# Altium schematic sheet SynchrBuckConverter.SchDoc(SynchrBuckConverter)
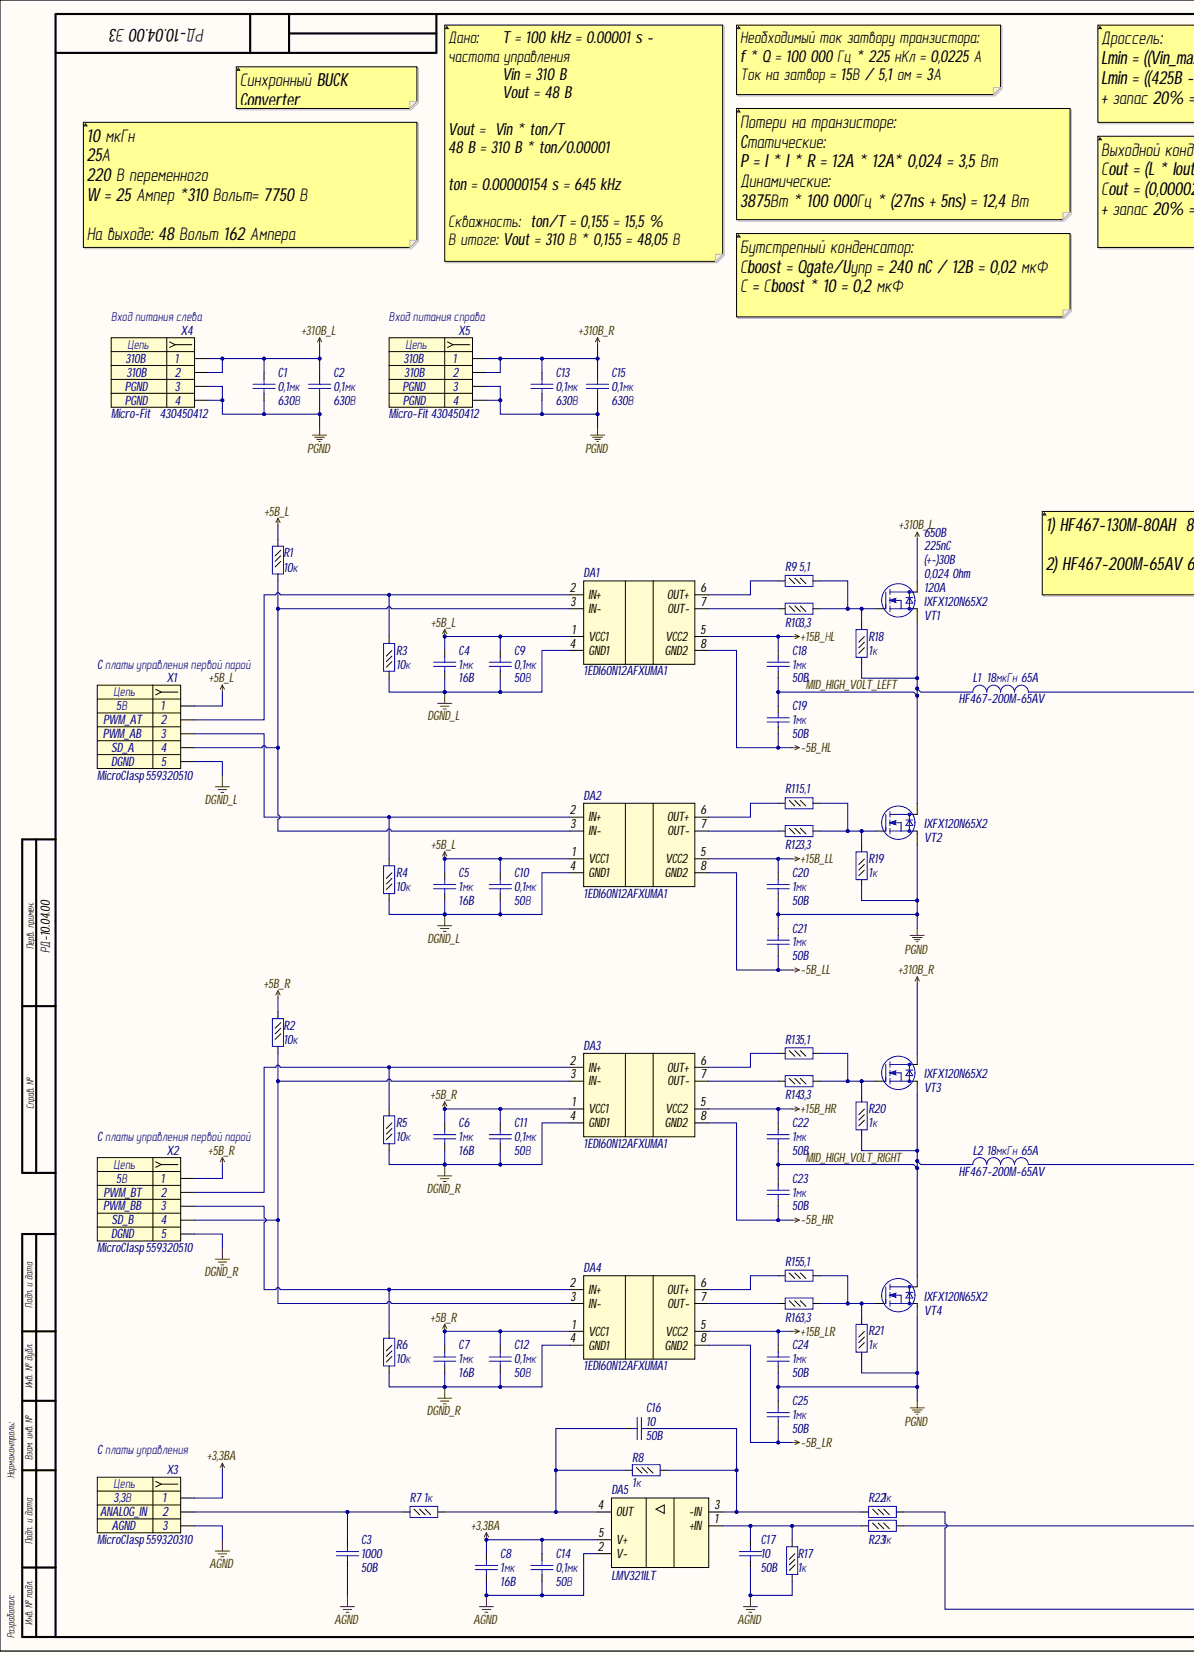
[diagram p0: SynchrBuckConverter.SchDoc, left]
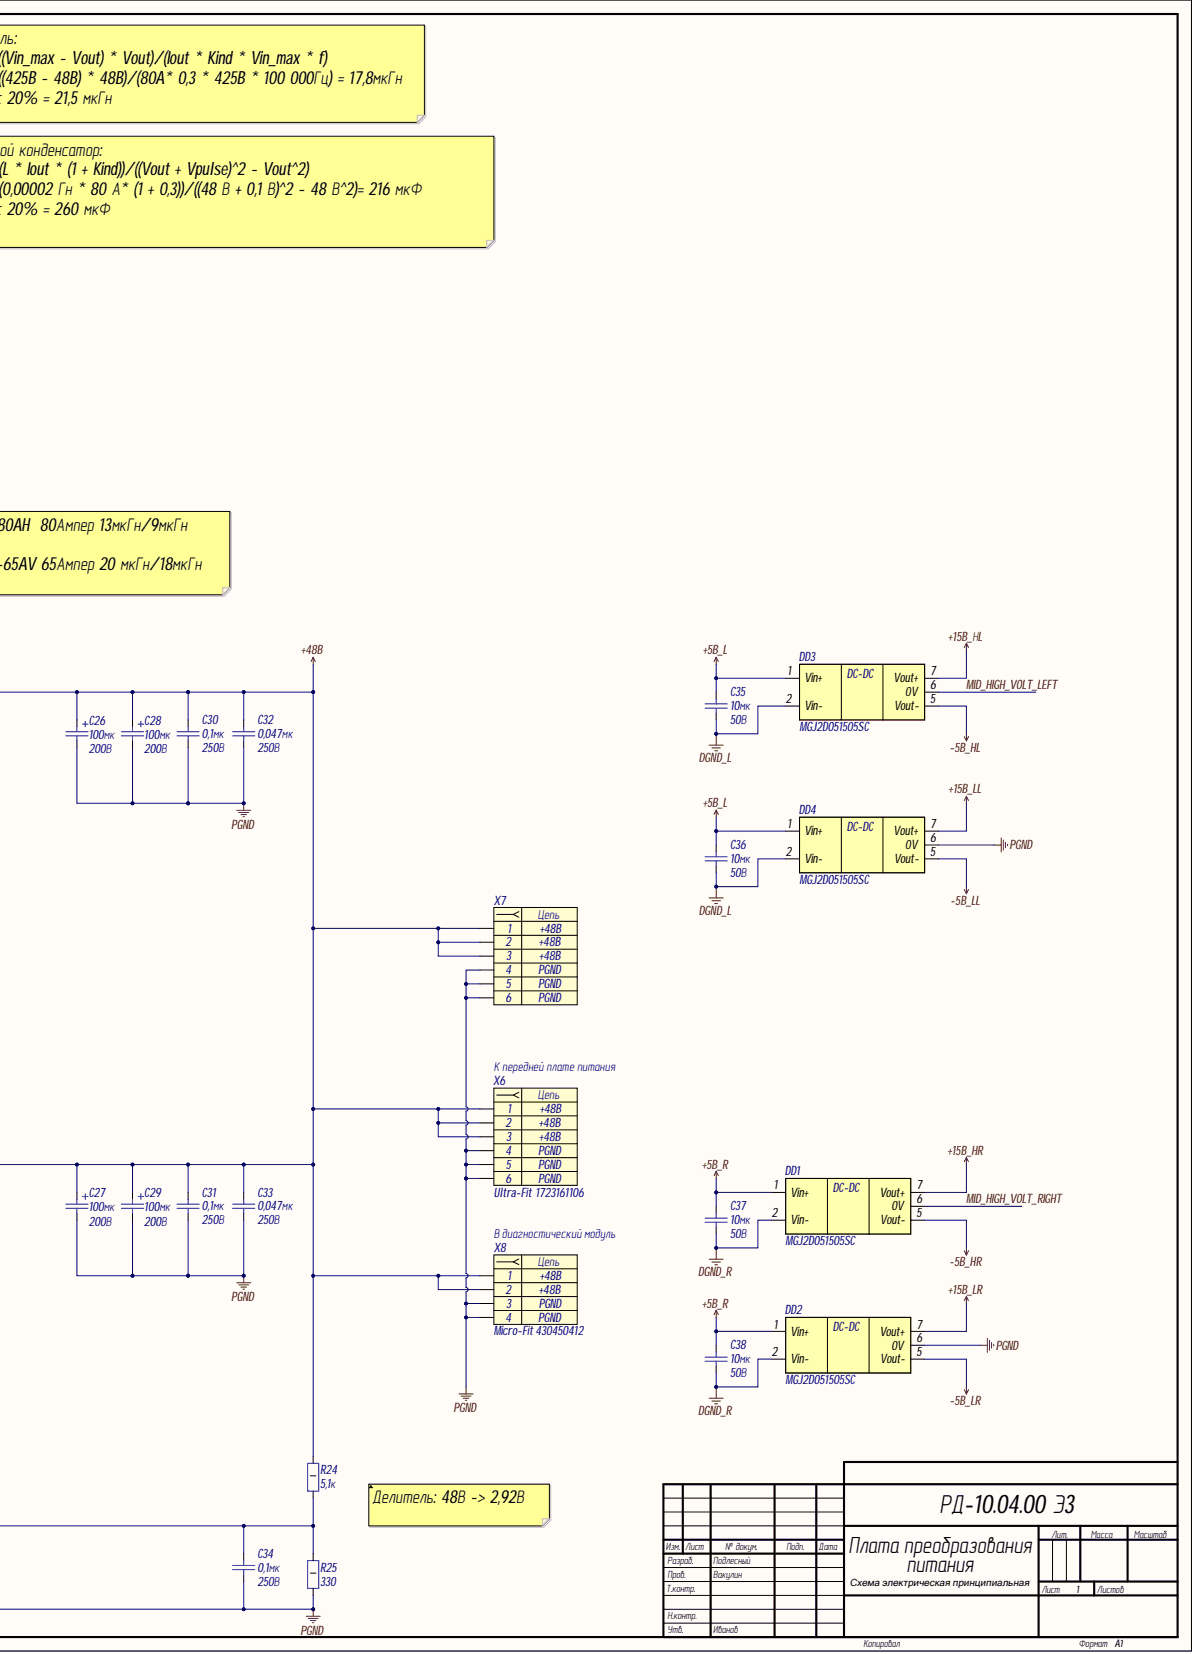
[diagram p1: SynchrBuckConverter.SchDoc, right]

## Parts
C1  0,1мк  Voltage: 630В  [C1812]
C2  0,1мк  Voltage: 630В  [C1812]
C3  value 1000  Voltage: 50B  [C0402]  (hidden comment: Capacitor)
C4  1мк  Voltage: 16B  [C0603]
C5  1мк  Voltage: 16B  [C0603]
C6  1мк  Voltage: 16B  [C0603]
C7  1мк  Voltage: 16B  [C0603]
C8  1мк  Voltage: 16B  [C0603]
C9  0,1мк  Voltage: 50В  [C0402]
C10  0,1мк  Voltage: 50В  [C0402]
C11  0,1мк  Voltage: 50В  [C0402]
C12  0,1мк  Voltage: 50В  [C0402]
C13  0,1мк  Voltage: 630В  [C1812]
C14  value 0,1мк  Voltage: 50В  [C0402]  (hidden comment: Capacitor)
C15  0,1мк  Voltage: 630В  [C1812]
C16  value 10  Voltage: 50B  [C0402]  (hidden comment: Capacitor)
C17  value 10  Voltage: 50B  [C0402]  (hidden comment: Capacitor)
C18  1мк  Voltage: 50B  [C0805]
C19  1мк  Voltage: 50B  [C0805]
C20  1мк  Voltage: 50B  [C0805]
C21  1мк  Voltage: 50B  [C0805]
C22  1мк  Voltage: 50B  [C0805]
C23  1мк  Voltage: 50B  [C0805]
C24  1мк  Voltage: 50B  [C0805]
C25  1мк  Voltage: 50B  [C0805]
C26  value 100мк  Voltage: 200В  [Cap_SMD_radial_polar(18x21.5)]  (hidden comment: Capacitor_pol)
C27  value 100мк  Voltage: 200В  [Cap_SMD_radial_polar(18x21.5)]  (hidden comment: Capacitor_pol)
C28  value 100мк  Voltage: 200В  [Cap_SMD_radial_polar(18x21.5)]  (hidden comment: Capacitor_pol)
C29  value 100мк  Voltage: 200В  [Cap_SMD_radial_polar(18x21.5)]  (hidden comment: Capacitor_pol)
C30  0,1мк  Voltage: 250В  [C1206]
C31  0,1мк  Voltage: 250В  [C1206]
C32  0,047мк  Voltage: 250В  [C1206]
C33  0,047мк  Voltage: 250В  [C1206]
C34  0,1мк  Voltage: 250В  [C1206]
C35  10мк  Voltage: 50В  [C1210]
C36  10мк  Voltage: 50В  [C1210]
C37  10мк  Voltage: 50В  [C1210]
C38  10мк  Voltage: 50В  [C1210]
DA1  1EDI60N12AF  Part Number: 1EDI60N12AFXUMA1  [PG-DSO-8]
DA2  1EDI60N12AF  Part Number: 1EDI60N12AFXUMA1  [PG-DSO-8]
DA3  1EDI60N12AF  Part Number: 1EDI60N12AFXUMA1  [PG-DSO-8]
DA4  1EDI60N12AF  Part Number: 1EDI60N12AFXUMA1  [PG-DSO-8]
DA5  LMV321ILT  [SOT23-5]
DD1  Part Number: MGJ2D051505SC  [SIP7]  (hidden comment: MGJ2DXX XXXX S C-RXX)
DD2  Part Number: MGJ2D051505SC  [SIP7]  (hidden comment: MGJ2DXX XXXX S C-RXX)
DD3  Part Number: MGJ2D051505SC  [SIP7]  (hidden comment: MGJ2DXX XXXX S C-RXX)
DD4  Part Number: MGJ2D051505SC  [SIP7]  (hidden comment: MGJ2DXX XXXX S C-RXX)
L1  value 18мкГн  Rated Current: 65A  [HF467-200M-65AV]  (hidden comment: Inductor)
L2  value 18мкГн  Rated Current: 65A  [HF467-200M-65AV]  (hidden comment: Inductor)
R1  value 10к  [R0402]  (hidden comment: Resistor_0,062Вт)
R2  value 10к  [R0402]  (hidden comment: Resistor_0,062Вт)
R3  value 10к  [R0402]  (hidden comment: Resistor_0,062Вт)
R4  value 10к  [R0402]  (hidden comment: Resistor_0,062Вт)
R5  value 10к  [R0402]  (hidden comment: Resistor_0,062Вт)
R6  value 10к  [R0402]  (hidden comment: Resistor_0,062Вт)
R7  value 1к  [R0402]  (hidden comment: Resistor_0,062Вт)
R8  value 1к  [R0402]  (hidden comment: Resistor_0,062Вт)
R9  value 5,1  [R0603]  (hidden comment: Resistor_0,062Вт)
R10  value 3,3  [R0603]  (hidden comment: Resistor_0,062Вт)
R11  value 5,1  [R0603]  (hidden comment: Resistor_0,062Вт)
R12  value 3,3  [R0603]  (hidden comment: Resistor_0,062Вт)
R13  value 5,1  [R0603]  (hidden comment: Resistor_0,062Вт)
R14  value 3,3  [R0603]  (hidden comment: Resistor_0,062Вт)
R15  value 5,1  [R0603]  (hidden comment: Resistor_0,062Вт)
R16  value 3,3  [R0603]  (hidden comment: Resistor_0,062Вт)
R17  value 1к  [R0402]  (hidden comment: Resistor_0,062Вт)
R18  value 1к  [R0603]  (hidden comment: Resistor_0,062Вт)
R19  value 1к  [R0603]  (hidden comment: Resistor_0,062Вт)
R20  value 1к  [R0603]  (hidden comment: Resistor_0,062Вт)
R21  value 1к  [R0603]  (hidden comment: Resistor_0,062Вт)
R22  value 1к  [R0402]  (hidden comment: Resistor_0,062Вт)
R23  value 1к  [R0402]  (hidden comment: Resistor_0,062Вт)
R24  value 5,1к  [R2512]  (hidden comment: Resistor_1Вт)
R25  value 330  [R2512]  (hidden comment: Resistor_1Вт)
VT1  Continuous drain current: 120A  Gate-to-source voltage: (+-)30B  Part Number: IXFX120N65X2  Rds: 0,024 Ohm  Total gate charge: 225nC  [TO-247_V]  (hidden comment: N-MOSFET)
VT2  Part Number: IXFX120N65X2  [TO-247_V]  (hidden comment: N-MOSFET)
VT3  Part Number: IXFX120N65X2  [TO-247_V]  (hidden comment: N-MOSFET)
VT4  Part Number: IXFX120N65X2  [TO-247_V]  (hidden comment: N-MOSFET)
X1  C платы управления первой парой  Contact_1: 5В  Contact_2: PWM_AT  Contact_3: PWM_AB  Contact_4: SD_A  Contact_5: DGND  Part Name: MicroClasp  Part Number: 559320510  [MOLEX_559320510]
X2  C платы управления первой парой  Contact_1: 5В  Contact_2: PWM_BT  Contact_3: PWM_BB  Contact_4: SD_B  Contact_5: DGND  Part Name: MicroClasp  Part Number: 559320510  [MOLEX_559320510]
X3  C платы управления  Contact_1: 3,3В  Contact_2: ANALOG_IN  Contact_3: AGND  Part Name: MicroClasp  Part Number: 559320310  [MOLEX_559320310]
X4  Вход питания слева  Contact_1: 310B  Contact_3: PGND  Part Name: Micro-Fit  Part Number: 430450412  [MOLEX_430450412]
X5  Вход питания справа  Contact_1: 310B  Contact_3: PGND  Part Name: Micro-Fit  Part Number: 430450412  [MOLEX_430450412]
X6  К передней плате питания  Contact_1: +48B  Contact_4: PGND  Part Name: Ultra-Fit  Part Number: 1723161106  [MOLEX_1723161106]
X7  Contact_1: +48B  Contact_4: PGND  [MOLEX_1722981106_HOLE]  (hidden comment: Header_6_sct)
X8  В диагностический модуль  Contact_1: +48B  Contact_3: PGND  Part Name: Micro-Fit  Part Number: 430450412  [MOLEX_430450412]

## Nets
+3,3BA: C8.2 C14.2 DA5.5(V+) X3.1
+5B_L: C4.2 C5.2 C9.2 C10.2 C35.2 C36.2 DA1.1(VCC1) DA2.1(VCC1) DD3.1(Vin+) DD4.1(Vin+) R1.1 X1.1
+5B_R: C6.2 C7.2 C11.2 C12.2 C37.2 C38.2 DA3.1(VCC1) DA4.1(VCC1) DD1.1(Vin+) DD2.1(Vin+) R2.1 X2.1
+15B_HR: C22.2 DA3.5(VCC2) DD1.7(Vout+)
+15B_LL: C20.2 DA2.5(VCC2) DD4.7(Vout+)
+15B_LR: C24.2 DA4.5(VCC2) DD2.7(Vout+)
+15B_НL: C18.2 DA1.5(VCC2) DD3.7(Vout+)
+48B: C26.1 C27.1 C28.1 C29.1 C30.2 C31.2 C32.2 C33.2 L1.2 L2.2 R24.2 X6.1 X6.2 X6.3 X7.1 X7.2 X7.3 X8.1 X8.2
+310B_L: C1.1 C2.1 VT1.2 X4.1 X4.2
+310B_R: C13.1 C15.1 VT3.2 X5.1 X5.2
-5B_HL: C19.1 DA1.8(GND2) DD3.5(Vout-)
-5B_HR: C23.1 DA3.8(GND2) DD1.5(Vout-)
-5B_LL: C21.1 DA2.8(GND2) DD4.5(Vout-)
-5B_LR: C25.1 DA4.8(GND2) DD2.5(Vout-)
AGND: C3.1 C8.1 C14.1 C17.2 DA5.2(V-) R17.1 X3.3
DGND_L: C4.1 C5.1 C9.1 C10.1 C35.1 C36.1 DA1.4(GND1) DA2.4(GND1) DD3.2(Vin-) DD4.2(Vin-) R3.1 R4.1 X1.5
DGND_R: C6.1 C7.1 C11.1 C12.1 C37.1 C38.1 DA3.4(GND1) DA4.4(GND1) DD1.2(Vin-) DD2.2(Vin-) R5.1 R6.1 X2.5
PGND: C1.2 C2.2 C13.2 C15.2 C20.1 C21.2 C24.1 C25.2 C26.2 C27.2 C28.2 C29.2 C30.1 C31.1 C32.1 C33.1 C34.1 DD2.6(0V) DD4.6(0V) R19.1 R21.1 R22.2 R25.1 VT2.3 VT4.3 X4.3 X4.4 X5.3 X5.4 X6.4 X6.5 X6.6 X7.4 X7.5 X7.6 X8.3 X8.4
MID_HIGH_VOLT_LEFT: C18.1 C19.2 DD3.6(0V) L1.1 R18.1 VT1.3 VT2.2
MID_HIGH_VOLT_RIGHT: C22.1 C23.2 DD1.6(0V) L2.1 R20.1 VT3.3 VT4.2
NetC3_2: C3.2 R7.1 X3.2
NetC16_1: C16.1 DA5.4(OUT) R7.2 R8.1
NetC16_2: C16.2 DA5.3(-IN) R8.2 R22.1
NetC17_1: C17.1 DA5.1(+IN) R17.2 R23.1
NetC34_2: C34.2 R23.2 R24.1 R25.2
NetDA1_2: DA1.2(IN+) R3.2 X1.2
NetDA1_3: DA1.3(IN-) DA2.3(IN-) R1.2 X1.4
NetDA1_6: DA1.6(OUT+) R9.1
NetDA1_7: DA1.7(OUT-) R10.1
NetDA2_2: DA2.2(IN+) R4.2 X1.3
NetDA2_6: DA2.6(OUT+) R11.1
NetDA2_7: DA2.7(OUT-) R12.1
NetDA3_2: DA3.2(IN+) R5.2 X2.2
NetDA3_3: DA3.3(IN-) DA4.3(IN-) R2.2 X2.4
NetDA3_6: DA3.6(OUT+) R13.1
NetDA3_7: DA3.7(OUT-) R14.1
NetDA4_2: DA4.2(IN+) R6.2 X2.3
NetDA4_6: DA4.6(OUT+) R15.1
NetDA4_7: DA4.7(OUT-) R16.1
NetR9_2: R9.2 R10.2 R18.2 VT1.1
NetR11_2: R11.2 R12.2 R19.2 VT2.1
NetR13_2: R13.2 R14.2 R20.2 VT3.1
NetR15_2: R15.2 R16.2 R21.2 VT4.1

## Library data of the parts
C1 C2 C13 C15 = cap237: Конденсатор; Manufacture: Murata; Part Name: Ceramic; Part Number: GRM43DR72J104KW01L; Database Table Name: Capacitors$; TKE: X7R; Component Kind: Standard; id: cap237; Library Name: MOP44.DbLib; Value: 0,1мк
C3 = cap28: Конденсатор; Manufacture: Murata; Part Name: Ceramic; Part Number: GRM1555C1H102J; Database Table Name: Capacitors$; TKE: NP0; Component Kind: Standard; id: cap28; Library Name: MOP44.DbLib
C4-C8 = cap133: Конденсатор; Manufacture: Murata; Part Name: Ceramic; Part Number: GRM188R71C105KA12D**; Database Table Name: Capacitors$; TKE: X7R; Component Kind: Standard; id: cap133; Library Name: MOP44.DbLib; Value: 1мк
C9-C12 C14 = cap277: Конденсатор; Manufacture: Murata; Part Name: Ceramic; Part Number: GRM155R71H104KE14D; Database Table Name: Capacitors$; tga: 0,10%; TKE: X7R; Component Kind: Standard; id: cap277; Library Name: MOP44.DbLib
  C9-C12: Value: 0,1мк
C16 C17 = cap5: Конденсатор; Manufacture: Murata; Part Name: Ceramic; Part Number: GRM1535C1H100J; Database Table Name: Capacitors$; TKE: NP0; Component Kind: Standard; id: cap5; Library Name: MOP44.DbLib
C18-C25 = cap151: Конденсатор; Manufacture: Murata; Part Name: Ceramic; Part Number: GRM21BR71H105K; Database Table Name: Capacitors$; TKE: X7R; Component Kind: Standard; id: cap151; Library Name: MOP44.DbLib; Value: 1мк
C26-C29 = cap325: Конденсатор полярный; Manufacture: Panasonic; Part Name: Electrolit; Part Number: EEV-EB2D101M; Database Table Name: Capacitors$; tga: 0,20%; Component Kind: Standard; id: cap325; Library Name: MOP44.DbLib
C30 C31 C34 = cap179: Конденсатор; Manufacture: Murata; Part Name: Ceramic; Part Number: GRM31CR72E104K; Database Table Name: Capacitors$; TKE: X7R; Component Kind: Standard; id: cap179; Library Name: MOP44.DbLib; Value: 0,1мк
C32 C33 = cap177: Конденсатор; Manufacture: Murata; Part Name: Ceramic; Part Number: GRM31CR72E473K; Database Table Name: Capacitors$; TKE: X7R; Component Kind: Standard; id: cap177; Library Name: MOP44.DbLib; Value: 0,047мк
C35-C38 = cap297: Конденсатор; Manufacture: TDK; Part Name: MLCC; Part Number: C3225X7R1H106K250AC; Database Table Name: Capacitors$; tga: 0,10%; TKE: X7R; Component Kind: Standard; id: cap297; Library Name: MOP44.DbLib; Value: 10мк
DA1-DA4 = driver33: Single Channel MOSFET Gate Driver IC; Manufacture: Infineon Technologies; Database Table Name: Driver$; Max Operating Supply Voltage: 17B; Maximum output current: 6А; Maximum Power Dissipation: 400 mW; Min Operating Supply Voltage: 3B; Component Kind: Standard; id: driver33; Library Name: MOP44.DbLib; Part Name: Single Channel MOSFET Gate Driver IC
DA5 = amp10: HIGH-DRIVE OPERATIONAL AMPLIFIERS WITH SHUTDOWN; Manufacture: ST Microelectronics; Part Name: Micropower Operational Amplifiers; Bandwidth: 1MHz; Channels: 1; CMRR: 85dB; Database Table Name: Amplifier$; Input Bias Current: 10nA; Offset voltage: 0,1mB; PSRR: 80dB; Rail-to-rail: yes; Supply Current max: 200uA; Supply Voltage: 2,7-6B; Component Kind: Standard; id: amp10; Library Name: MOP44.DbLib; Part Number: LMV321ILT
DD1-DD4 = pwr144: DC/DC преобразователь; Manufacturer: Murata Power Solutions; Part Name: Isolated 2W Gate Drive DC-DC Converters; Current: 80mA/40mA; Database Table Name: PowerTransducer$; Input Voltage: 5B; Output Voltage: 15B/-5В; Power output: 2W; Component Kind: Standard; id: pwr144; Library Name: MOP44.DbLib; Type: Isolated
L1 L2 = ind218: EMI Suppression Filter; Manufacture: Coil Winding Specialis; Part Name: Сustom Inductor 18uH 80Amps; Database Table Name: Inductor$; Component Kind: Standard; id: ind218; Library Name: MOP44.DbLib; Part Number: HF467-200M-65AV
R1-R6 = res294: Резистор; Manufacture: Yageo; Part Number: RC0402FR-0710KL; Accuracy: 0,01; Database Table Name: Resistor$; Component Kind: Standard; id: res294; Library Name: MOP44.DbLib; Part Name: Resistor; Power: 0,062Вт
R7 R8 R17 R22 R23 = res270: Резистор; Manufacture: Yageo; Part Number: RC0402FR-071KL; Accuracy: 0,01; Database Table Name: Resistor$; Component Kind: Standard; id: res270; Library Name: MOP44.DbLib; Part Name: Resistor; Power: 0,062Вт
R9 R11 R13 R15 = res408: Резистор; Manufacture: Yageo; Part Number: RC0603FR-075R1L; Accuracy: 0,01; Database Table Name: Resistor$; Component Kind: Standard; id: res408; Library Name: MOP44.DbLib; Part Name: Resistor; Power: 0,062Вт
R10 R12 R14 R16 = res403: Резистор; Manufacture: Yageo; Part Number: RC0603FR-073R3L; Accuracy: 0,01; Database Table Name: Resistor$; Component Kind: Standard; id: res403; Library Name: MOP44.DbLib; Part Name: Resistor; Power: 0,062Вт
R18-R21 = res463: Резистор; Manufacture: Yageo; Part Number: RC0603FR-071KL; Accuracy: 0,01; Database Table Name: Resistor$; Component Kind: Standard; id: res463; Library Name: MOP44.DbLib; Part Name: Resistor; Power: 0,062Вт
R24 = res867: Резистор; Manufacture: Yageo; Part Number: RC2512FR-075K1; Accuracy: 0,01; Database Table Name: Resistor$; Power: 1Вт; Component Kind: Standard; id: res867; Library Name: MOP44.DbLib; Part Name: Resistor
R25 = res838: Резистор; Manufacture: Yageo; Part Number: RC2512FR-07330RL; Accuracy: 0,01; Database Table Name: Resistor$; Power: 1Вт; Component Kind: Standard; id: res838; Library Name: MOP44.DbLib; Part Name: Resistor
VT1 = mosfert30: Manufacturer: IXYS; Database Table Name: MOSFETTransistor$; Power dissipation: 1250W; Pulsed drain current: 480A; Structure: n-channel; V drain-source max: 650B; Component Kind: Standard; Description: N-MOSFET; id: mosfert30; Library Name: MOP44.DbLib
VT2-VT4 = mosfert30: Manufacturer: IXYS; Continuous drain current: 120A; Database Table Name: MOSFETTransistor$; Gate-to-source voltage: (+-)30B; Power dissipation: 1250W; Pulsed drain current: 480A; Rds: 0,024 Ohm; Structure: n-channel; Total gate charge: 225nC; V drain-source max: 650B; Component Kind: Standard; Description: N-MOSFET; id: mosfert30; Library Name: MOP44.DbLib
X1 X2 = connect98: Разъем - 5 конт. розетка; Manufacture: Molex; Database Table Name: Connector$; Etch: 2mm; Component Kind: Standard; id: connect98; Library Name: MOP44.DbLib
X3 = connect96: Разъем - 3 конт. розетка; Manufacture: Molex; Database Table Name: Connector$; Etch: 2mm; Component Kind: Standard; id: connect96; Library Name: MOP44.DbLib
X4 X5 X8 = connect114: Разъем - 4 конт. розетка; Manufacture: Molex; Database Table Name: Connector$; Etch: 3mm; Component Kind: Standard; Contact_4: PGND; id: connect114; Library Name: MOP44.DbLib
  X4 X5: Contact_2: 310B
  X8: Contact_2: +48B
X6 = connect137: Разъем - 6 конт. розетка; Manufacture: Molex; Database Table Name: Connector$; Etch: 3.5mm; Component Kind: Standard; Contact_2: +48B; Contact_3: +48B; Contact_5: PGND; Contact_6: PGND; id: connect137; Library Name: MOP44.DbLib
X7 = connect199: Разъем - 6 конт. розетка; Manufacture: Molex; Part Name: Ultra-Fit Vertical HOLE; Part Number: 1722981106; Database Table Name: Connector$; Etch: 3.5mm; Component Kind: Standard; Contact_2: +48B; Contact_3: +48B; Contact_5: PGND; Contact_6: PGND; id: connect199; Library Name: MOP44.DbLib
